# Altium schematic sheet Hydroponic_Schematic.SchDoc(Hydroponic_Schematic)
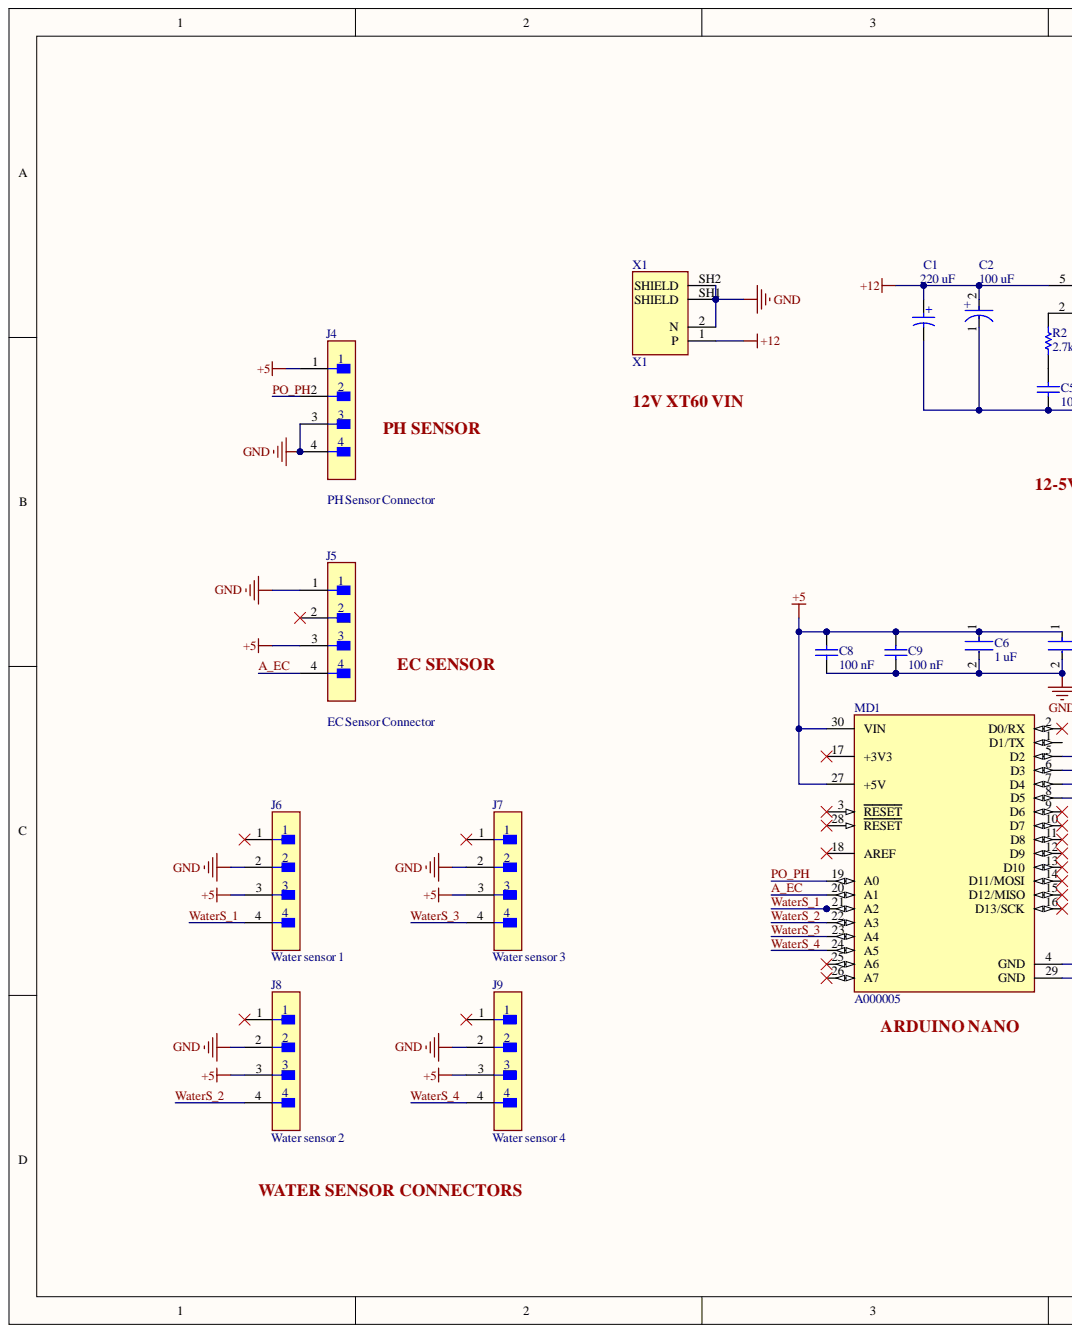
[diagram p0: Hydroponic_Schematic.SchDoc, left]
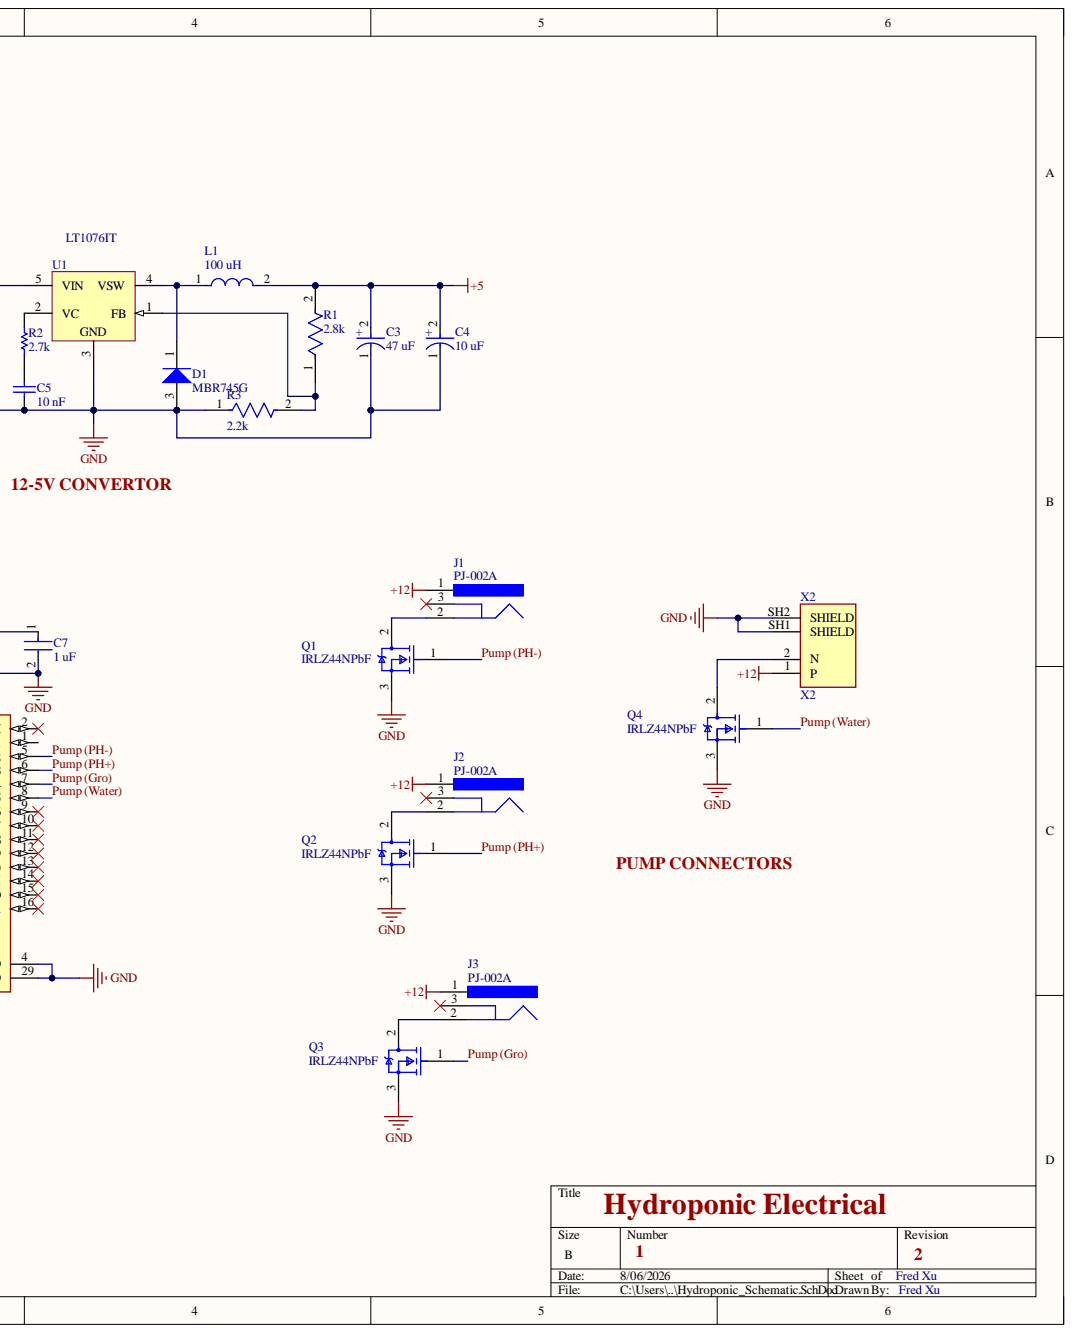
[diagram p1: Hydroponic_Schematic.SchDoc, right]

## Parts
C1  220 uF  [WCAP-ASLL_D6.3H7.7]
C2  100 uF  [FP-TGV10X10_5-MFG]
C3  47 uF  [FP-UUX1H470MNL6GS-MFG]
C4  10 uF  [FP-EDK9B-MFG]
C5  10 nF  [CAPC1608X87X35LL15T15]
C6  1 uF  [FP-CC0805-0_85-MFG]
C7  1 uF  [FP-CC0805-0_85-MFG]
C8  100 nF  [CAPC2013X140X50LL20T25]
C9  100 nF  [CAPC2013X140X50LL20T25]
D1  MBR745G  [ONSC-TO-220-2-221B-04_E]
J1  PJ-002A  [CUI-PJ-002A_V]
J2  PJ-002A  [CUI-PJ-002A_V]
J3  PJ-002A  [CUI-PJ-002A_V]
J4  PH Sensor Connector  [FP-B4B-XH-AM_LF_SN-MFG]
J5  EC Sensor Connector  [FP-B4B-XH-AM_LF_SN-MFG]
J6  Water sensor 1  [FP-B4B-XH-AM_LF_SN-MFG]
J7  Water sensor 3  [FP-B4B-XH-AM_LF_SN-MFG]
J8  Water sensor 2  [FP-B4B-XH-AM_LF_SN-MFG]
J9  Water sensor 4  [FP-B4B-XH-AM_LF_SN-MFG]
L1  100 uH  [FP-LQM21DH_70-IPC_C]
MD1  A000005  [FP-A000005-MFG]
Q1  IRLZ44NPbF  [FP-PG-TO220-3-MFG]
Q2  IRLZ44NPbF  [FP-PG-TO220-3-MFG]
Q3  IRLZ44NPbF  [FP-PG-TO220-3-MFG]
Q4  IRLZ44NPbF  [FP-PG-TO220-3-MFG]
R1  2.8k  [FP-RG2012-IPC_C]
R2  2.7k  [RESC0603(1608)_M]
R3  2.2k  [FP-AC0805-MFG]
U1  LT1076IT  [LT-T-5-S]
X1  X1  [PCBComponent_1]
X2  X2  [PCBComponent_1]

## Nets
+5: C3.2 C4.2 C6.1 C7.1 C8.2 C9.2 J4.1 J5.3 J6.3 J7.3 J8.3 J9.3 L1.2 MD1.27(+5V) MD1.30(VIN) R1.2
+12: C1.1 C2.2 J1.1 J2.1 J3.1 U1.5(VIN) X1.1(P) X2.1(P)
GND: C1.2 C2.1 C3.1 C4.1 C5.2 C6.2 C7.2 C8.1 C9.1 D1.3 J4.3 J4.4 J5.1 J6.2 J7.2 J8.2 J9.2 MD1.4(GND) MD1.29(GND) Q1.3 Q2.3 Q3.3 Q4.3 R3.1 U1.3 X1.2(N) X1.SH1(SHIELD) X1.SH2(SHIELD) X2.SH1(SHIELD) X2.SH2(SHIELD)
A_EC: J5.4 MD1.20(A1)
PO_PH: J4.2 MD1.19(A0)
Pump (Gro): MD1.7(D4) Q3.1
Pump (PH+): MD1.6(D3) Q2.1
Pump (PH-): MD1.5(D2) Q1.1
Pump (Water): MD1.8(D5) Q4.1
WaterS_1: J6.4 MD1.21(A2)
WaterS_2: J8.4 MD1.22(A3)
WaterS_3: J7.4 MD1.23(A4)
WaterS_4: J9.4 MD1.24(A5)
NetC5_1: C5.1 R2.1
NetD1_1: D1.1 L1.1 U1.4(VSW)
NetJ1_2: J1.2 Q1.2
NetJ2_2: J2.2 Q2.2
NetJ3_2: J3.2 Q3.2
NetQ4_2: Q4.2 X2.2(N)
NetR1_1: R1.1 R3.2 U1.1(FB)
NetR2_2: R2.2 U1.2(VC)

Not connected: J1 3; J2 3; J3 3; J5 2; J6 1; J7 1; J8 1; J9 1; MD1 1(D1/TX) 2(D0/RX) 3(RESET) 9(D6) 10(D7) 11(D8) 12(D9) 13(D10) 14(D11/MOSI) 15(D12/MISO) 16(D13/SCK) 17(+3V3) 18(AREF) 25(A6) 26(A7) 28(RESET)

## Library data of the parts
C1 = CMP-03913-53914500-3: Capacitance: 220µF; ESR (Equivalent Series Resistance): 246.927mΩ; Impedance: 360mΩ; Insulation Resistance: 454.545kΩ; Leakage Current: 13.86µA; Life (Hours): 2000hours; Material: Aluminium; Polarity: Polar; Ripple Current: 280mA; Tolerance: 20%; Voltage Rating: 6.3V; Case/Package: Radial; Component Kind: Standard; Description: 220 uF; Diameter: 6.3mm; Height: 7.7mm; Height - Seated (Max): 7.6962mm; Length: 7.7mm; Library Name: Altium Content Vault; Max Operating Temperature: 105°C; Min Operating Temperature: -55°C; Mount: Surface Mount; REACH SVHC: Unknown; Ripple Current (AC): 280mA; RoHS: Compliant; Voltage Rating (DC): 6.3V
C2 = CMP-35376-000017-1: CAP ALUM 100UF 20% 35V SMD; Component Kind: Standard; Library Name: Altium Content Vault
C3 = CMP-12462-000056-1: CAP ALUM 47UF 20% 50V SMD; Dissipation Factor: 0.1%; Lead Diameter: 1.1mm; Leakage Current: 70.5uA; Life (Hours): 2000Hour; Ripple Current: 167mA; Capacitance: 47uF; Case/Package: Radial; Component Kind: Standard; Construction: Cylindrical; Contact Plating: Tin; Diameter: 8mm; Features: General Purpose; Height: 10mm; Height - Seated (Max): 0.413inch; Lead Free: Lead Free; Lead Pitch: 3.1mm; Length: 10mm; Library Name: Altium Content Vault; Max Operating Temperature: 105°C; Min Operating Temperature: -55°C; Mount: Surface Mount; Package Quantity: 1; Packaging: Tape and Reel; Radiation Hardening: No; RoHS Compliant: Yes; Series: UX; Termination: SMD/SMT; Tolerance: 20%; Voltage: 50V; Voltage Rating: 50V; Voltage Rating (DC): 50V
C4 = CMP-03015-000003-1: CAP ALUM 10UF 20% 35V SMD; Manufacturer: Kemet; Manufacturer Part Number: EDK106M035A9BAA; Dissipation Factor: 16%; Leakage Current: 3.5uA; Life: 2000Hours; Material: Aluminum; Ripple Current: 24mA; Automotive: No; Capacitance: 10uF; Category: Cap; Component Kind: Standard; ComponentLink2Description: Datasheet; ComponentLink2URL: https://content.kemet.com/datasheets/KEM_A4001_EDK.pdf; Device Class L1: Passive Components; Device Class L2: Capacitors; Device Class L3: Aluminum Electrolytic Capacitors; Digikey Description: CAP ALUM 10UF 20% 35V SMD; Footprint Url: http://www.kemet.com/Lists/FileStore/smd_radial_ordering_codes_tech.pdf; Height: 5.65mm; Lead Free: Yes; Library Name: Altium Content Vault; Octopart: https://octopart.com/edk106m035a9baa-kemet-26722193; Package: EDK9B; RoHS: TRUE; Temperature: -40°C to +85°C; Temperature Range High: +85°C; Temperature Range Low: -40°C; Tolerance: 20%; Value: 10uF; Voltage Rating: 35V
C5 = CMP-2006-03842-1: Manufacturer: Kemet; Part Number: C0603S103K4RACTU; Component Kind: Standard; Library Name: Altium Content Vault
C6 C7 = CMP-03422-000746-1: CAP CER 1UF 10V X5R 0805; Manufacturer: Yageo; Manufacturer Part Number: CC0805KKX5R6BB105; Material: Ceramic; Tolerance: 0.1; Automotive: No; Capacitance: 1 uF; Category: Cap; Component Kind: Standard; ComponentLink2Description: Datasheet; ComponentLink2URL: http://www.yageo.com/documents/recent/UPY-GPHC_Y5V_6.3V-to-50V_8.pdf; Device Class L1: Passive Components; Device Class L2: Capacitors; Device Class L3: Ceramic Capacitors; Digikey Description: CAP CER 1UF 10V X5R 0805; Footprint Url: http://www.yageo.com/exep/pages/download/literatures/UPY-C_GEN_24.pdf; Height: 0.95mm; Ipc Land Pattern Name: CAPC200125X85; Lead Free: Yes; Library Name: Altium Content Vault; Mouser Description: Multilayer Ceramic Capacitors MLCC - SMD/SMT 1.0uF 10V X5R 10%; Octopart: https://octopart.com/cc0805kkx5r6bb105-yageo-9292777; Package: CC0805-0.85; RoHS: TRUE; Temperature: -30°C to +85°C; Temperature Characteristic: X5R; Temperature Range High: +85°C; Temperature Range Low: -30°C; Value: 1 uF; Voltage Rating: 10 V
C8 C9 = CMP-2007-04740-1: Manufacturer: AVX Interconnect / Elco; Part Number: 08051C104M4Z2A; Component Kind: Standard; Library Name: Altium Content Vault
D1 = CMP-1055-00593-1: SWITCHMODE(TM) Power Rectifier, 2-Pin TO-220, Pb-Free, Tube; Manufacturer: On Semiconductor; Configuration: Single; IFSM Max (A): 150; Component Kind: Standard; ComponentLink1Description: Manufacturer URL; ComponentLink1URL: http://www.onsemi.com/; ComponentLink2Description: Datasheet; ComponentLink2URL: http://www.onsemi.cn/pub_link/Collateral/MBR735-D.PDF; ComponentLink3Description: Package Specification; ComponentLink3URL: http://www.onsemi.com/pub_link/Collateral/BYW29-D.PDF; DatasheetVersion: Rev. 8; Library Name: Altium Content Vault; Mounting Technology: Through Hole; PackageDescription: 2-Pin Flange Mount, Vertical Straight Lead, Body 9.97 x 4.44 mm, Pitch 5.08 mm; PackageReference: TO-220-2-221B-04_E; PackageVersion: Rev. E; Packing: Tube; PartNumber: MBR745G; RoHS: TRUE
J1-J3 = CMP-2000-06895-2: Through Hole Right Angle DC Power Jack, 2.5 A, 2 mm Center Pin, 3 Position, -25 to 85 degC, RoHS, Bulk; Manufacturer: CUIInc.; Brand: CUI; Current Rating: 2.5A; Inside Contact Diameter: 2mm; Insulation Resistance: 50MOhms; Outside Contact Diameter: 6.5mm; Voltage Rating: 24V; Component Kind: Standard; Connector Type: DCPowerJack; Contact Gender: Male; Contact Material: Copper; Contact Plating: Nickel; Datasheet URL: http://www.cui.com/product/resource/pj-002a.pdf; Datasheet Version: Rev. 1.03, 04/2016; Factory Pack Quantity: 500; Flammability Rating: UL94V-0; Housing Material: PolybutyleneTerephthalate(PBT); Library Name: Altium Content Vault; Manufacturer URL: http://www.cui.com/; Mounting Angle: Right; Mounting Style: ThroughHole; Mounting Technology: ThroughHole; Operating Temperature Range: -25Cto+85C; Package Description: 3-Pin Through Hole Device, Body 14.4 x 9 mm, Height 11 mm; Package Reference: PJ-002A; Product: Jacks; Product Category: DCPowerConnectors; RoHS: True; Termination Style: ThroughHole
J4-J9 = CMP-17439-000117-1: CONN HEADER VERT 4POS 2.5MM; Contact Resistance: 20mR; Gender: Male; Mated Stacking Heights: 9.8mm; Max Current Rating: 3A; Max Voltage Rating (AC): 250V; Number of Positions: 4; Color: Green; Component Kind: Standard; Connector Type: Header; Contact Finish - Mating: TIN; Contact Material: Brass; Contact Plating: Copper; Contact Shape: Square; Contact Type: Male Pin; Depth: 5.75mm; Fastening Type: Detent; Flammability Rating: UL94 V-0; Housing Color: White; Housing Material: Polyamide; Insulation Color: Natural; Insulation Material: Nylon; Lead Free: Lead Free; Length: 12.4mm; Library Name: Altium Content Vault; Max Operating Temperature: 85°C; Min Operating Temperature: -25°C; Mount: Through Hole; Number of Pins: 4; Number of Rows: 1; Orientation: Straight; Packaging: Bulk; Pitch: 2.5mm; Series: XH; Termination: Solder; Voltage Rating: 250V
L1 = CMP-06042-017057-1: Multilayer type Inductor for Power Lines for Automotive 100uH ±20% @1MHz, 160mA, Max DCR 4.095Ω, SRF 8MHz; Component Kind: Standard; Library Name: Altium Content Vault
MD1 = CMP-189986-000002-1: ARDUINO NANO ATMEGA328 EVAL BRD; Core Architecture: AVR; Data Bus Width: 8b; Evaluation Kit: Yes; Interface: I2C; Number of Bits: 8; Operating Supply Voltage: 12V; Component Kind: Standard; Height: 0.25inch; Length: 7inch; Library Name: Altium Content Vault; Package Quantity: 50; Packaging: Bulk; REACH SVHC: No SVHC; Series: AVR® ATmega; Weight: 0.358383oz; Width: 5inch
Q1-Q4 = CMP-32901-000488-1: MOSFET N-CH 55V 47A TO220AB; Drain to Source Resistance: 35mR; Element Configuration: Single; Gate to Source Voltage (Vgs): 16V; Input Capacitance: 1.7nF; Max Power Dissipation: 110W; Rds On Max: 22mR; Threshold Voltage: 2V; Turn-Off Delay Time: 26ns; Turn-On Delay Time: 11ns; Case/Package: TO-220; Component Kind: Standard; Continuous Drain Current (ID): 47A; Drain to Source Breakdown Voltage: 55V; Drain to Source Voltage (Vdss): 55V; Halogen Free: Halogen Free; Height: 0.6inch; Lead Free: Lead Free; Length: 0.414inch; Library Name: Altium Content Vault; Max Dual Supply Voltage: 55V; Max Operating Temperature: 175°C; Min Operating Temperature: -55°C; Mount: Through Hole; Number of Pins: 3; Packaging: Rail/Tube; Power Dissipation: 110W; REACH SVHC: No SVHC; RoHS Compliant: Yes; Width: 0.185inch
R1 = CMP-28537-010746-1: Automotive Metal Thin Film Chip Resistor, 0805, 2.8kΩ, 0.1%, 25ppm/°C, 0.125W, 150V; Max Power Dissipation: 125mW; Resistance: 2.8kR; Case Code (Imperial): 0805; Case Code (Metric): 2012; Case/Package: 0805; Component Kind: Standard; Features: Automotive AEC-Q200; Height: 0.02inch; Lead Free: Lead Free; Length: 0.079inch; Library Name: Altium Content Vault; Max Operating Temperature: 155°C; Min Operating Temperature: -55°C; Number of Terminations: 2; Package Quantity: 5000; Packaging: Tape and Reel; Power Rating: 125mW; Resistor Type: Thin Film; RoHS Compliant: Yes; Series: RG; Temperature Coefficient: 25ppm/°C; Termination: SMD/SMT; Tolerance: 0.1%; Voltage Rating: 150V; Voltage Rating (DC): 150V; Weight: 0.000194oz; Width: 1.2mm
R2 = CMP-1012-00084-1: 2K7 0.1W 5% 0603 (1608 Metric)  SMD; Case-EIA: 0603; Case-Metric: 1608; Value: 2700.00 Ohm; Component Kind: Standard; Library Name: Altium Content Vault; Power: 0.1 W; Technology: SMT; Tolerance: 5 %
R3 = CMP-03412-037614-1: RES SMD 2.2K OHM 5% 1/8W 0805; Manufacturer: Yageo; Manufacturer Part Number: AC0805JR-072K2L; Automotive Grade: Grade 0; Resistance: 2.2KΩ; Tolerance: 0.05; Value: 2.2KΩ; Voltage Rating: 150V; Automotive: Yes; Category: Res; Component Kind: Standard; ComponentLink2Description: Datasheet; ComponentLink2URL: http://www.yageo.com/documents/recent/PYu-AC_51_RoHS_L_6.pdf; Composition: Thick Film; Device Class L1: Passive Components; Device Class L2: Resistors; Device Class L3: Chip SMD Resistors; Digikey Description: RES SMD 2.2K OHM 5% 1/8W 0805; Footprint Url: http://www.yageo.com/exep/pages/download/literatures/PYu-R_Mount_10.pdf; Height: 0.6mm; Ipc Land Pattern Name: RESC200125X50; Lead Free: Yes; Library Name: Altium Content Vault; Mouser Description: Thick Film Resistors - SMD 1/8W 2.2K ohm 5% AEC-Q200; Octopart: https://octopart.com/ac0805jr-072k2l-yageo-30510982; Package: AC0805; Power Rating: 1/8W; RoHS: TRUE; Series: AC; Temperature: -55°C to +155°C; Temperature Range High: +155°C; Temperature Range Low: -55°C
U1 = CMP-0491-02059-1: Step-Down Switching Regulator, 5-pin FM (T-5), -40 to 85 degC; Manufacturer: Linear Technology; Number of Outputs: 1; Component Kind: Standard; ComponentLink1Description: Manufacturer URL; ComponentLink1URL: http://www.linear.com/; ComponentLink2Description: Datasheet; ComponentLink2URL: http://cds.linear.com/docs/en/datasheet/1074fds.pdf; ComponentLink3Description: Package Specification; ComponentLink3URL: http://cds.linear.com/docs/en/packaging/TO-220_5_05-08-1421.pdf; DatasheetVersion: Rev. D; Library Name: Altium Content Vault; Mounting Technology: Through Hole; PackageDescription: 5-Pin Plastic TO-220 (Standard), Body 10.224 x 8.89 mm, Pitch 1.7 mm; PackageReference: T-5; PackageVersion: Aug-10; PartNumber: LT1076IT; RoHS: FALSE
X1 X2 = xt60: Component Kind: Standard; Library Name: EPICS_Schematics.SchLib
